# Altium schematic sheet IMX6ULL_MINI_ENET2&PIN.SchDoc(IMX6ULL_MINI_ENET2&PIN)
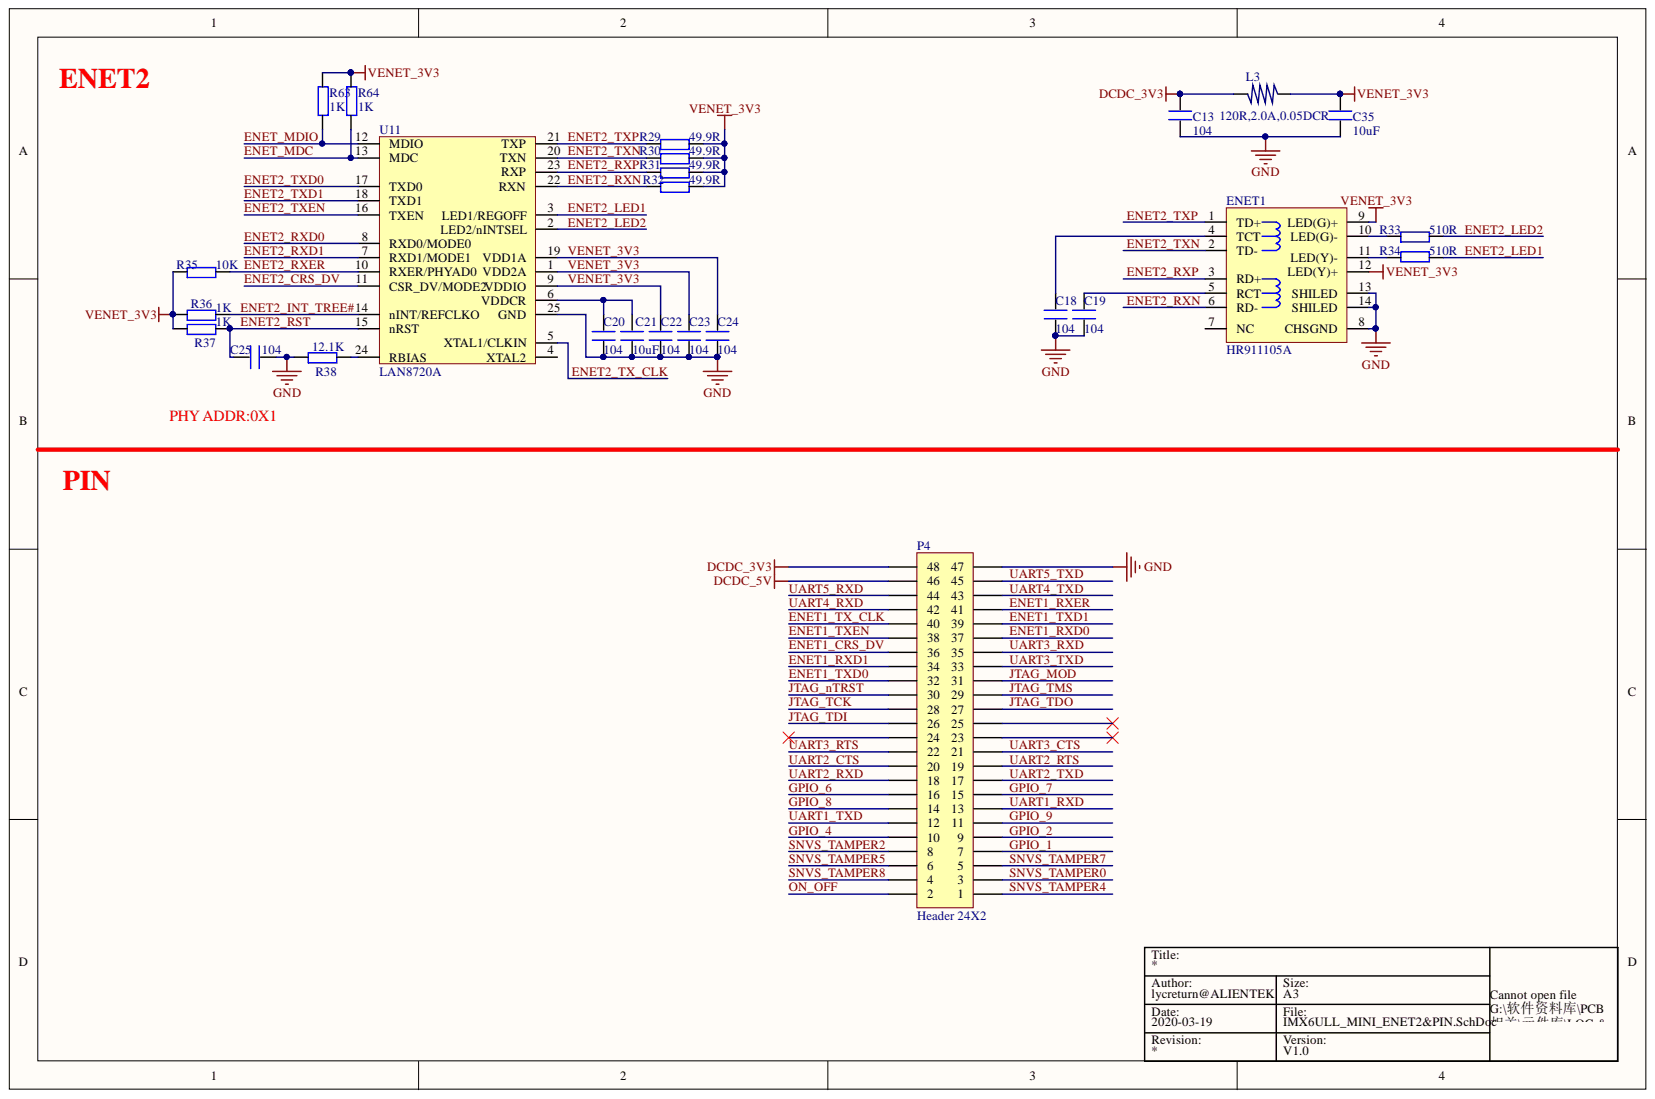
[diagram p0: IMX6ULL_MINI_ENET2&PIN.SchDoc]

## Parts
C13  104  [C 0603]
C18  104  [C 0603]
C19  104  [C 0603]
C20  104  [C 0603]
C21  10uF  [0603P]
C22  104  [C 0603]
C23  104  [C 0603]
C24  104  [C 0603]
C25  104  [C 0603]
C35  10uF  [0805]
ENET1  HR911105A  [RJ-45A12]
L3  120R,2.0A,0.05DCR  [l 0603]
P4  Header 24X2  [HDR2X24]
R29  49.9R  [R 0603]
R30  49.9R  [R 0603]
R31  49.9R  [R 0603]
R32  49.9R  [R 0603]
R33  510R  [R 0603]
R34  510R  [R 0603]
R35  10K  [R 0603]
R36  1K  [R 0603]
R37  1K  [R 0603]
R38  12.1K  [R 0603]
R63  1K  [R 0603]
R64  1K  [R 0603]
U11  LAN8720A  [QFN24]

## Nets
DCDC_3V3: C13.2 L3.2 P4.48
DCDC_5V: P4.46
GND: C13.1 C18.1 C19.1 C20.2 C21.2 C22.2 C23.2 C24.2 C25.1 C35.1 ENET1.8(CHSGND) ENET1.13(SHILED) ENET1.14(SHILED) P4.47 R38.1 U11.25(GND)
ENET1_CRS_DV: P4.36
ENET1_RXD0: P4.37
ENET1_RXD1: P4.34
ENET1_RXER: P4.41
ENET1_TX_CLK: P4.40
ENET1_TXD0: P4.32
ENET1_TXD1: P4.39
ENET1_TXEN: P4.38
ENET2_CRS_DV: U11.11(CSR_DV/MODE2)
ENET2_INT_TREE#: R36.2 U11.14(nINT/REFCLKO)
ENET2_LED1: R34.1 U11.3(LED1/REGOFF)
ENET2_LED2: R33.2 U11.2(LED2/nINTSEL)
ENET2_RST: C25.2 R37.2 U11.15(nRST)
ENET2_RXD0: U11.8(RXD0/MODE0)
ENET2_RXD1: U11.7(RXD1/MODE1)
ENET2_RXER: R35.2 U11.10(RXER/PHYAD0)
ENET2_RXN: ENET1.6(RD-) R32.1 U11.22(RXN)
ENET2_RXP: ENET1.3(RD+) R31.1 U11.23(RXP)
ENET2_TX_CLK: U11.5(XTAL1/CLKIN)
ENET2_TXD0: U11.17(TXD0)
ENET2_TXD1: U11.18(TXD1)
ENET2_TXEN: U11.16(TXEN)
ENET2_TXN: ENET1.2(TD-) R30.1 U11.20(TXN)
ENET2_TXP: ENET1.1(TD+) R29.1 U11.21(TXP)
ENET_MDC: R64.1 U11.13(MDC)
ENET_MDIO: R63.1 U11.12(MDIO)
GPIO_1: P4.7
GPIO_2: P4.9
GPIO_4: P4.10
GPIO_6: P4.16
GPIO_7: P4.15
GPIO_8: P4.14
GPIO_9: P4.11
JTAG_MOD: P4.31
JTAG_NTRST: P4.30
JTAG_TCK: P4.28
JTAG_TDI: P4.26
JTAG_TDO: P4.27
JTAG_TMS: P4.29
ON_OFF: P4.2
SNVS_TAMPER0: P4.3
SNVS_TAMPER2: P4.8
SNVS_TAMPER4: P4.1
SNVS_TAMPER5: P4.6
SNVS_TAMPER7: P4.5
SNVS_TAMPER8: P4.4
UART1_RXD: P4.13
UART1_TXD: P4.12
UART2_CTS: P4.20
UART2_RTS: P4.19
UART2_RXD: P4.18
UART2_TXD: P4.17
UART3_CTS: P4.21
UART3_RTS: P4.22
UART3_RXD: P4.35
UART3_TXD: P4.33
UART4_RXD: P4.42
UART4_TXD: P4.43
UART5_RXD: P4.44
UART5_TXD: P4.45
VENET_3V3: C22.1 C23.1 C24.1 C35.2 ENET1.9(LED(G)+) ENET1.12(LED(Y)+) L3.1 R29.2 R30.2 R31.2 R32.2 R35.1 R36.1 R37.1 R63.2 R64.2 U11.1(VDD2A) U11.9(VDDIO) U11.19(VDD1A)
NetC18_2: C18.2 ENET1.4(TCT)
NetC19_2: C19.2 ENET1.5(RCT)
NetC20_1: C20.1 C21.1 U11.6(VDDCR)
NetENET1_10: ENET1.10(LED(G)-) R33.1
NetENET1_11: ENET1.11(LED(Y)-) R34.2
NetR38_2: R38.2 U11.24(RBIAS)

Not connected: ENET1 7(NC); P4 23 24 25; U11 4(XTAL2)

## Library data of the parts
C13 C18-C25 C35 = C: Component Kind: Standard
  C13 C35: Library Name: IMX6UL_ALPHA_V1.0.IntLib
  C18-C25: Library Name: 电阻-电容-电感.SchLib
ENET1 = HR911105A: 带灯以太网接口; 版权归属: 源创客; BOM名称: 带灯以太网接口; Component Kind: Standard; Library Name: 接口类.SchLib; 学习资源: www.ooocke.com
L3 = L: Component Kind: Standard; Library Name: IMX6UL_ALPHA_V1.0.IntLib
P4 = Header 24X2: Header, 24-Pin, Dual row; Component Kind: Standard; LatestRevisionDate: 17-Jul-2002; LatestRevisionNote: Re-released for DXP Platform.; Library Name: Miscellaneous Connectors.IntLib; Signal Integrity: Connector; Publisher: Altium Limited
R29-R38 R63 R64 = R: Component Kind: Standard; Library Name: 电阻-电容-电感.SchLib
U11 = LAN8720: ETH PHY; Component Kind: Standard; Library Name: IMX6UL_ALPHA_V1.0.IntLib
